# Altium schematic sheet Cartridge PCB.SchDoc
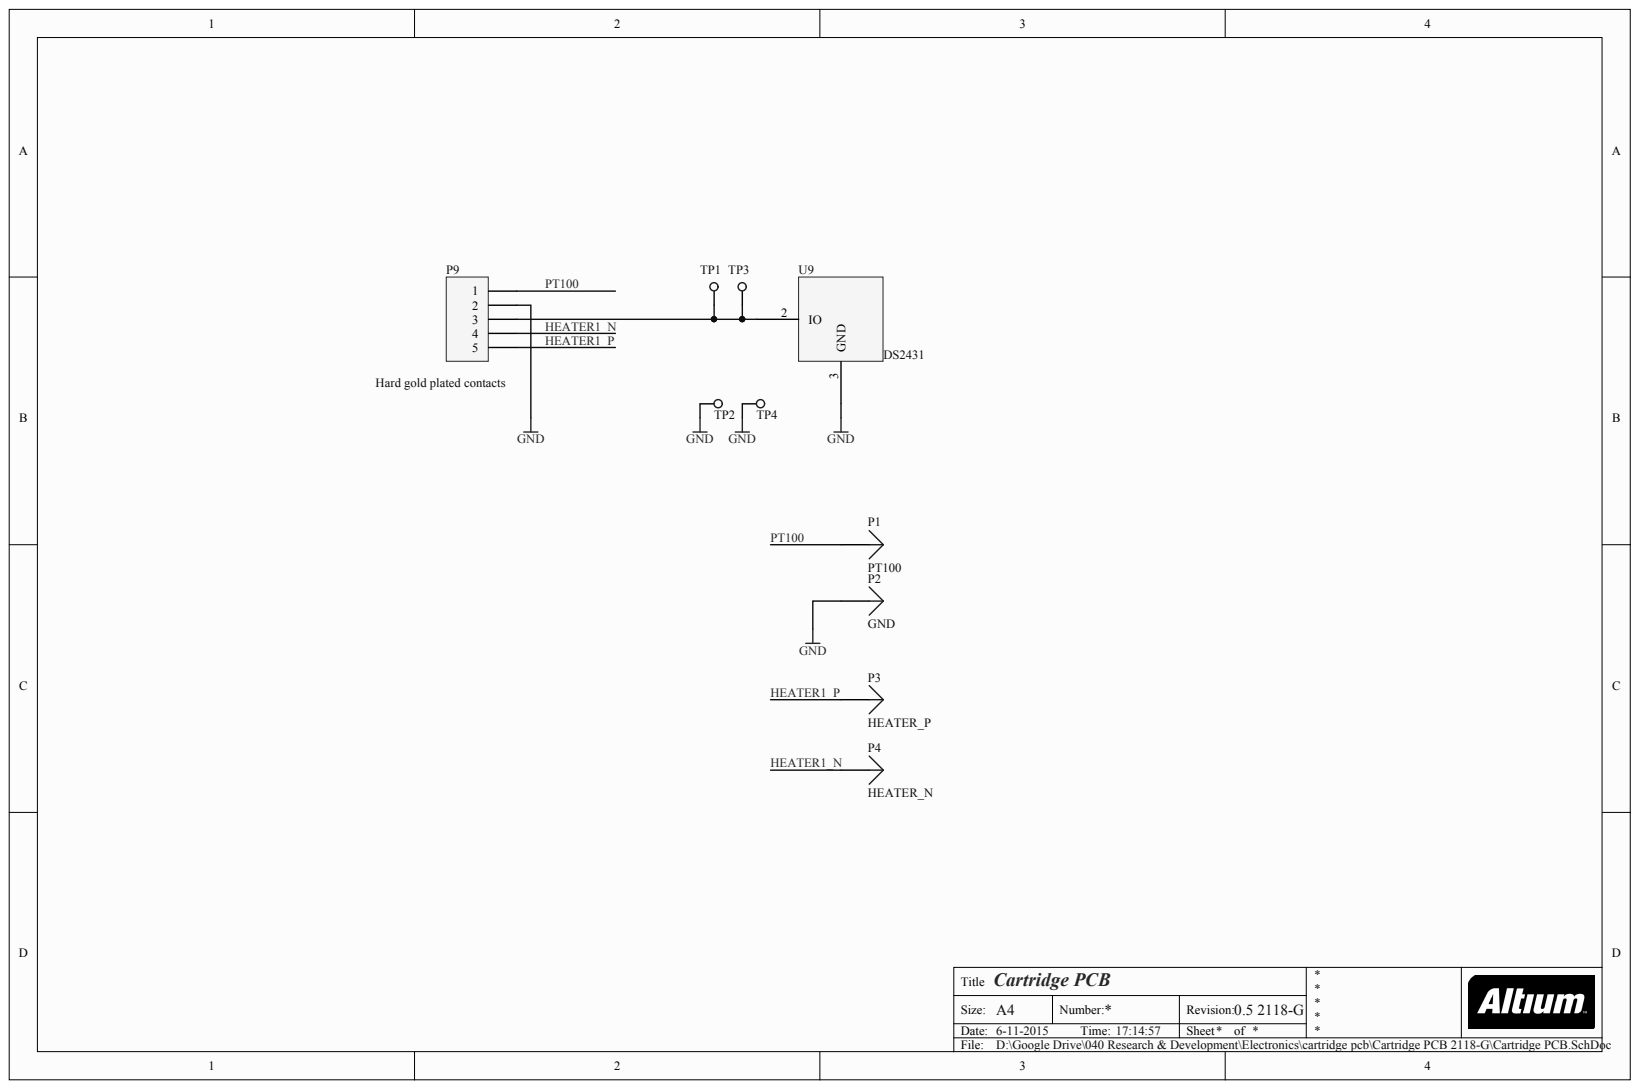
[diagram p0: Cartridge PCB.SchDoc]

## Parts
P1  PT100  [AVX POKE HOME CONTACT 2MM]
P2  GND  [AVX POKE HOME CONTACT 2MM]
P3  HEATER_P  [AVX POKE HOME CONTACT 2MM]
P4  HEATER_N  [AVX POKE HOME CONTACT 2MM]
P9  Hard gold plated contacts  [Mating contacts]
TP1  2mm  [TESTPOINT 2mm]  (value not drawn)  (no BOM)
TP2  2mm  [TESTPOINT 2mm]  (value not drawn)  (no BOM)
TP3  2mm  [TESTPOINT 2mm]  (value not drawn)  (no BOM)
TP4  2mm  [TESTPOINT 2mm]  (value not drawn)  (no BOM)
U9  DS2431  [TDFN-6]

## Nets
GND: P2.1 P9.2 TP2.1 TP4.1 U9.3
HEATER1_N: P4.1 P9.4
HEATER1_P: P3.1 P9.5
PT100: P1.1 P9.1
NetP9_3: P9.3 TP1.1 TP3.1 U9.2

## Library data of the parts
P1-P4 = Plug: Mfg: AVX; Mfg code: 70-9296-001-002-006; Component Kind: Standard; Description: Plug; LatestRevisionDate: 17-Jul-2002; LatestRevisionNote: Re-released for DXP Platform.; Library Name: Miscellaneous Connectors.IntLib; Signal Integrity: Connector; Publisher: Altium Limited
P9 = Header 5: Header, 5-Pin; Component Kind: Standard; LatestRevisionDate: 17-Jul-2002; LatestRevisionNote: Re-released for DXP Platform.; Library Name: Miscellaneous Connectors.IntLib; Publisher: Altium Limited
TP1-TP4 = (no symbol name): Testpoint, 2mm, round; Remarks: Not a component; Faber ItemCode: -; Max soldering temp: -; Mfg: -; Mfg code: -; Supplier: -; Supplier code: -; Value: -; Library Name: Faber_SCH_lib.SchLib
U9 = Component_1: Mfg: Maxim; Mfg code: DS2431Q+T&R; Component Kind: Standard; Library Name: Cartridge PCB.SchLib
